# Altium schematic sheet General Overview.SchDoc("General Overview")
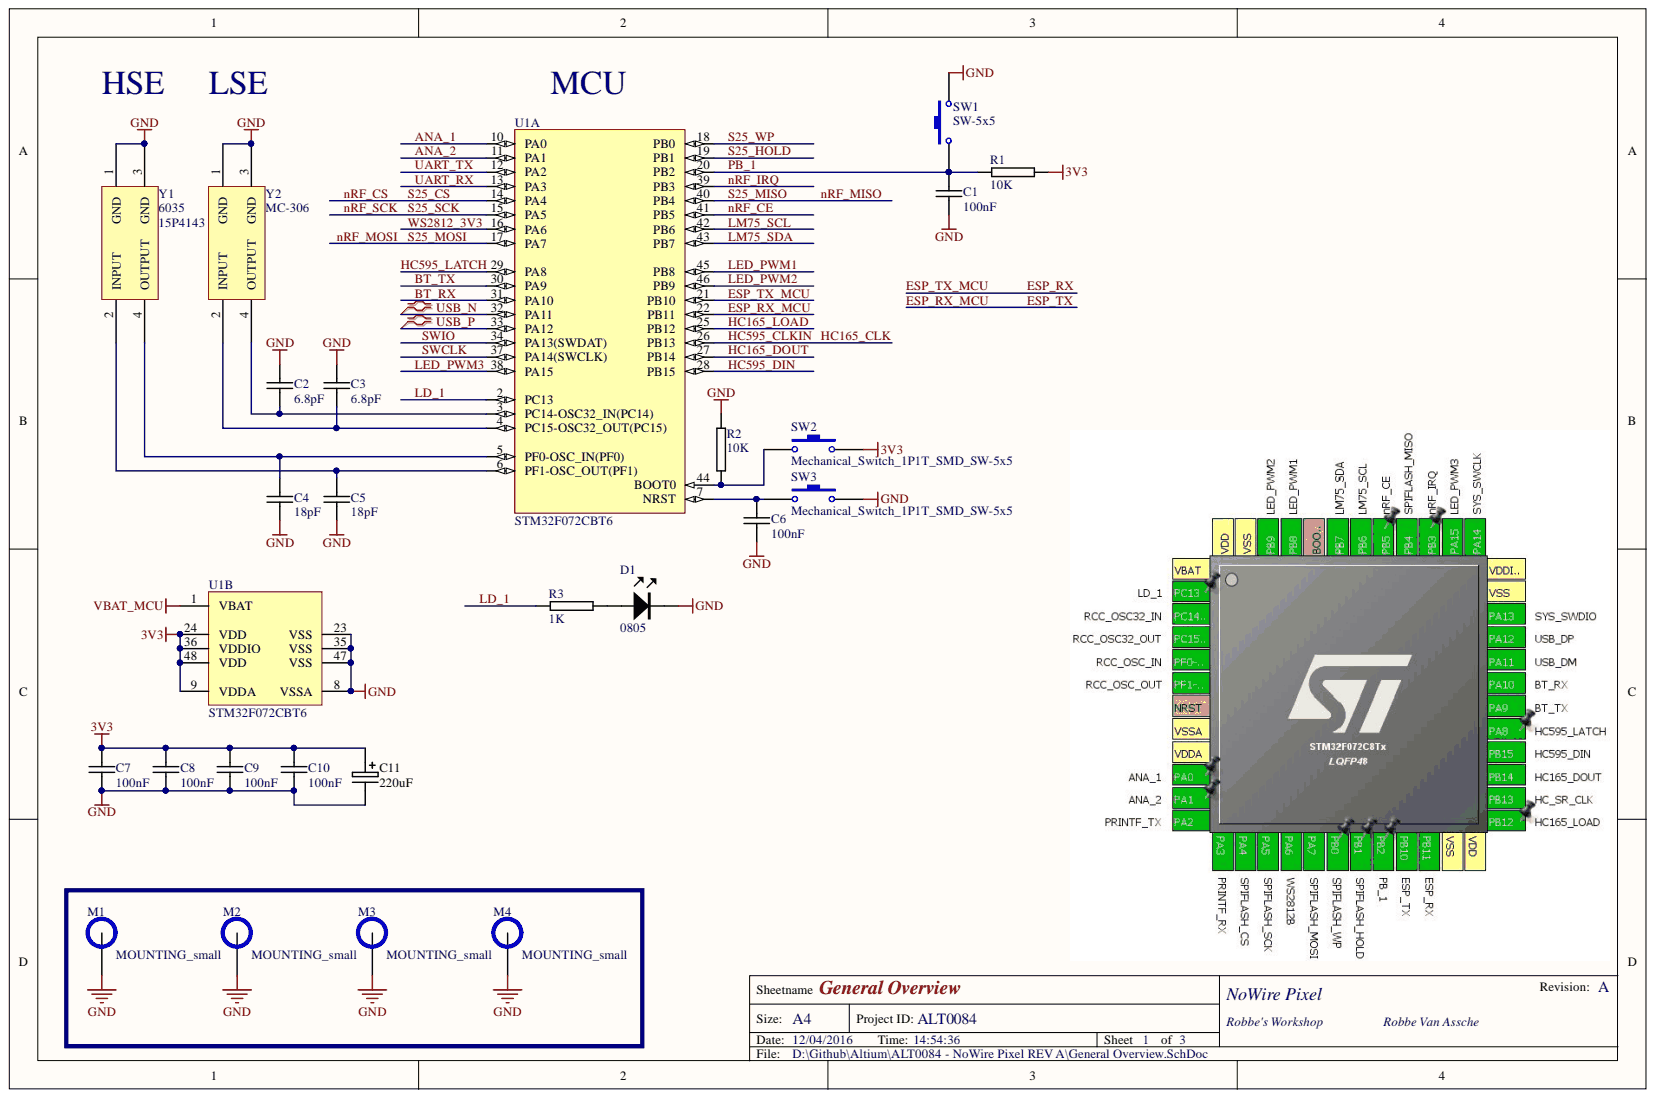
[diagram p0: General Overview.SchDoc]

## Parts
C1  100nF  [C0402]
C2  6.8pF  [C0402]
C3  6.8pF  [C0402]
C4  18pF  [C0402]
C5  18pF  [C0402]
C6  100nF  [C0402]
C7  100nF  [C0402]
C8  100nF  [C0402]
C9  100nF  [C0402]
C10  100nF  [C0402]
C11  220uF  [C+5MM]
D1  0805  [0805LED]
M1  MOUNTING_small  [MOUNTING M3 small]
M2  MOUNTING_small  [MOUNTING M3 small]
M3  MOUNTING_small  [MOUNTING M3 small]
M4  MOUNTING_small  [MOUNTING M3 small]
R1  10K  [R0402]
R2  10K  [R0402]
R3  1K  [R0402]
SW1  SW-5x5  [1P1T_SW_5x5]
SW2  Mechanical_Switch_1P1T_SMD_SW-5x5  [1P1T_SW_5x5]
SW3  Mechanical_Switch_1P1T_SMD_SW-5x5  [1P1T_SW_5x5]
U1  STM32F072CBT6  [STM-LQFP48_N]  (parts A,B)
Y1  6035  Supplier Part Number 1: 15P4143  [XTAL 6035]
Y2  MC-306  [XTAL MC-306]

## Nets
3V3: C7.1 C8.1 C9.1 C10.1 C11.+ R1.2 SW2.2 U1.9(VDDA) U1.24(VDD) U1.36(VDDIO) U1.48(VDD)
GND: C1.2 C2.1 C3.1 C4.2 C5.2 C6.2 C7.2 C8.2 C9.2 C10.2 C11.- D1.K M1.1 M2.1 M3.1 M4.1 R2.1 SW1.2 SW3.2 U1.8(VSSA) U1.23(VSS) U1.35(VSS) U1.47(VSS) Y1.1(GND) Y1.3(GND) Y2.1(GND) Y2.3(GND)
VBAT_MCU: U1.1(VBAT)
ANA_1: U1.10(PA0)
ANA_2: U1.11(PA1)
BT_RX: U1.31(PA10)
BT_TX: U1.30(PA9)
ESP_RX: U1.21(PB10)
ESP_RX_MCU: U1.22(PB11)
HC165_CLK: U1.26(PB13)
HC165_DOUT: U1.27(PB14)
HC165_LOAD: U1.25(PB12)
HC595_DIN: U1.28(PB15)
HC595_LATCH: U1.29(PA8)
LD_1: R3.1 U1.2(PC13)
LED_PWM1: U1.45(PB8)
LED_PWM2: U1.46(PB9)
LED_PWM3: U1.38(PA15)
LM75_SCL: U1.42(PB6)
LM75_SDA: U1.43(PB7)
NRF_CE: U1.41(PB5)
NRF_CS: U1.14(PA4)
NRF_IRQ: U1.39(PB3)
NRF_MISO: U1.40(PB4)
NRF_MOSI: U1.17(PA7)
NRF_SCK: U1.15(PA5)
PB_1: C1.1 R1.1 SW1.1 U1.20(PB2)
S25_HOLD: U1.19(PB1)
S25_WP: U1.18(PB0)
SWCLK: U1.37(PA14(SWCLK))
SWIO: U1.34(PA13(SWDAT))
UART_RX: U1.13(PA3)
UART_TX: U1.12(PA2)
USB_N: U1.32(PA11)
USB_P: U1.33(PA12)
WS2812_3V3: U1.16(PA6)
NetC2_2: C2.2 U1.3(PC14-OSC32_IN(PC14)) Y2.4(OUTPUT)
NetC3_2: C3.2 U1.4(PC15-OSC32_OUT(PC15)) Y2.2(INPUT)
NetC4_1: C4.1 U1.5(PF0-OSC_IN(PF0)) Y1.4(OUTPUT)
NetC5_1: C5.1 U1.6(PF1-OSC_OUT(PF1)) Y1.2(INPUT)
NetC6_1: C6.1 SW3.1 U1.7(NRST)
NetD1_A: D1.A R3.2
NetR2_2: R2.2 SW2.1 U1.44(BOOT0)

## Library data of the parts
C1-C10 = Passives_Capacitors_0402_TBD: CAPACITOR 0402 CERAMIC; Component Kind: Standard; Library Name: RVA_Passives_Capacitors_0402.SchLib
C11 = 220UF-5MM: CAPACITOR POLARISED; Component Kind: Standard; Library Name: RVA_Passives_Capacitors_Elco_THT.SchLib
D1 = LED_SMD_0805: Component Kind: Standard; Library Name: RVA_LED_SMD_0805.SchLib
M1-M4 = MOUNTING_small: MOUNTING HOLE - NON INSTALLABLE; Component Kind: Standard; Library Name: RVA_Mechanical_THT.SchLib
R1-R3 = Passives_Resistors_0402_TBD: RESISTOR 0402 VALUE TO BE DETERMINED; Component Kind: Standard; Library Name: RVA_Passives_Resistors_0402.SchLib
SW1-SW3 = Mechanical_Switch_1P1T_SMD_SW-5x5: Switch 5x5; Component Kind: Standard; Library Name: RVA_Mechanical_Switch_1P1T_SMD.SchLib
U1 = Microcontrollers_ST_ARM_STM32F0_STM32F072CBT6: PartNumber: STM32F051C8T6; Core: ARM Cortex-M0; FLASH Size (Prog) (kB): 64; I/Os (High Current): 39; Internal RAM Size (kB): 8; Operating Frequency(F) (Processor speed) (MHz): 48; Packing: Tray; Supply Voltage(Vcc) min (V): 2; Component Kind: Standard; ComponentLink1Description: Manufacturer URL; ComponentLink1URL: http://www.st.com/web/en/home.html; ComponentLink2Description: Datasheet; ComponentLink2URL: http://www.st.com/st-web-ui/static/active/en/resource/technical/document/datasheet/DM00039193.pdf; ComponentLink3Description: Package Specification; ComponentLink3URL: http://www.st.com/st-web-ui/static/active/en/resource/technical/document/datasheet/DM00058181.pdf; DatasheetVersion: Rev. 3; Mounting Technology: Surface Mount; PackageDescription: 48-Pin Low Profile Quad Flat Package, 7 x 7 mm Body, 0.5 mm Pitch; PackageReference: LQFP48; PackageVersion: Rev. 6, 06/2013; Supplier 1: Digi-Key; Supplier 2: Farnell; Supplier 3: Newark; Supplier 4: Newark; Supplier 5: Mouser; Supplier Part Number 1: 497-12893-ND; Supplier Part Number 2: 2115065; Supplier Part Number 3: 05W5802; Supplier Part Number 4: 90T0636; Supplier Part Number 5: 511-STM32F051C8T6; Library Name: RVA_Microcontrollers_ST_ARM_STM32F0.SchLib
Y1 = 6035 Quartz: Component Kind: Standard; Supplier 1: Newark; Library Name: RVA_Reference_Crystal Oscillator_SMD.SchLib
Y2 = 32k Quartz: Component Kind: Standard; Library Name: RVA_Reference_Crystal Oscillator_SMD.SchLib
